# Altium schematic sheet I2CInterface.SchDoc(I2CInterface)
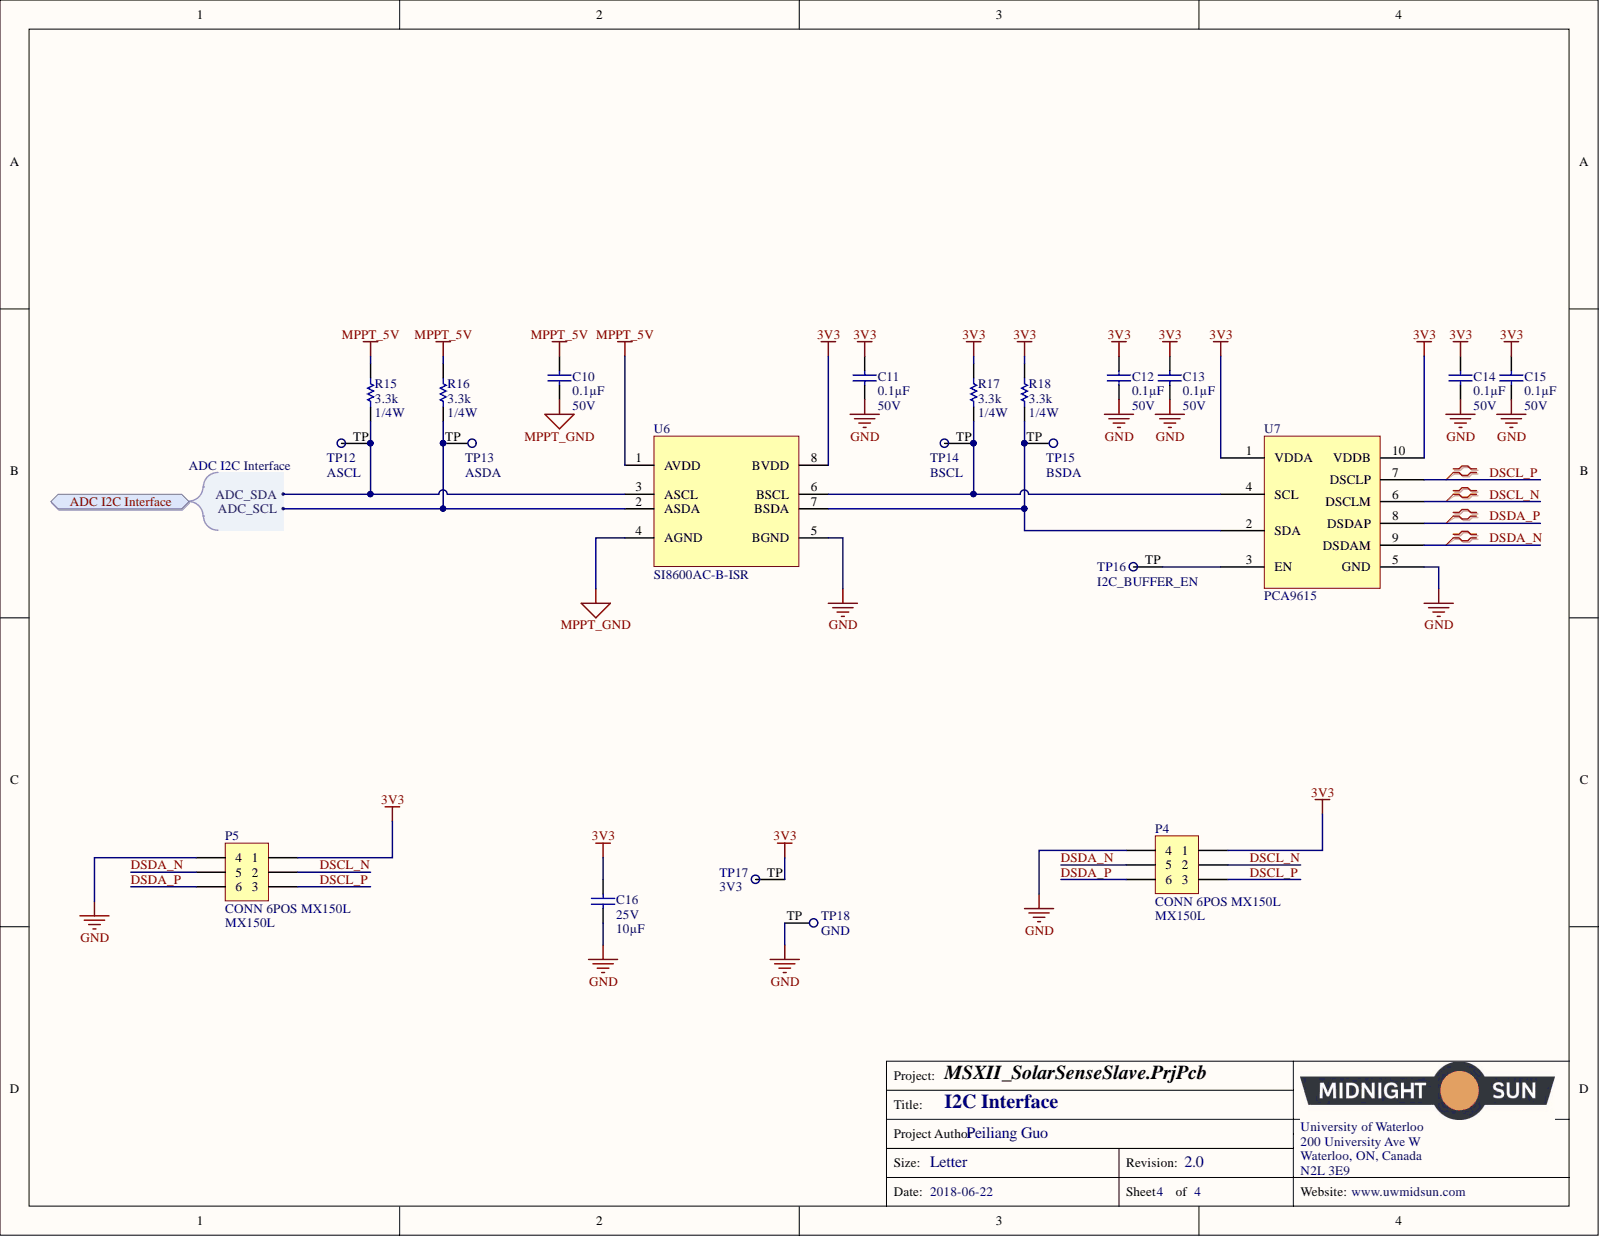
[diagram p0: I2CInterface.SchDoc]

## Parts
C10  Voltage: 50V  Capacitance: 0.1µF  [CAP, 0603]  (hidden comment: CAP CER 0.1UF 50V 10% X7R 0603)
C11  Voltage: 50V  Capacitance: 0.1µF  [CAP, 0603]  (hidden comment: CAP CER 0.1UF 50V 10% X7R 0603)
C12  Voltage: 50V  Capacitance: 0.1µF  [CAP, 0603]  (hidden comment: CAP CER 0.1UF 50V 10% X7R 0603)
C13  Voltage: 50V  Capacitance: 0.1µF  [CAP, 0603]  (hidden comment: CAP CER 0.1UF 50V 10% X7R 0603)
C14  Voltage: 50V  Capacitance: 0.1µF  [CAP, 0603]  (hidden comment: CAP CER 0.1UF 50V 10% X7R 0603)
C15  Voltage: 50V  Capacitance: 0.1µF  [CAP, 0603]  (hidden comment: CAP CER 0.1UF 50V 10% X7R 0603)
C16  Voltage - Rated: 25V  Capacitance: 10µF  [CAP, 0805]  (hidden comment: CAP CER 10uF 25V 10% X5R 0805)
P4  CONN 6POS MX150L  series: MX150L  [CONN, 6POS MX150L]
P5  CONN 6POS MX150L  series: MX150L  [CONN, 6POS MX150L]
R15  Resistance: 3.3k  Power: 1/4W  [RES, 0603]  (hidden comment: RES 3.3K OHM 1% 1/4W 0603)
R16  Resistance: 3.3k  Power: 1/4W  [RES, 0603]  (hidden comment: RES 3.3K OHM 1% 1/4W 0603)
R17  Resistance: 3.3k  Power: 1/4W  [RES, 0603]  (hidden comment: RES 3.3K OHM 1% 1/4W 0603)
R18  Resistance: 3.3k  Power: 1/4W  [RES, 0603]  (hidden comment: RES 3.3K OHM 1% 1/4W 0603)
TP12  ASCL  [Test Point]  (no BOM)
TP13  ASDA  [Test Point]  (no BOM)
TP14  BSCL  [Test Point]  (no BOM)
TP15  BSDA  [Test Point]  (no BOM)
TP16  I2C_BUFFER_EN  [Test Point]  (no BOM)
TP17  3V3  [Test Point]  (no BOM)
TP18  GND  [Test Point]  (no BOM)
U6  Manufacturer Part Number 1: SI8600AC-B-ISR  [IC DGTL ISO 3.75KV I2C 8SOIC NARROW BODY]  (hidden comment: IC DGTL ISO I2C 3.75KV 2CH 8SOIC)
U7  Base Part Number: PCA9615  [TSSOP50P490X110-10N]  (hidden comment: IC DIFFERENTIAL I2C BUFFER PCA9615)

## Ports
ADC I2C Interface, ADC I2C Interface.ADC_SCL, ADC I2C Interface.ADC_SDA

## Nets
3V3: C11.1 C12.1 C13.1 C14.1 C15.1 C16.1 P4.1 P5.1 R17.1 R18.1 TP17.TP U6.8(BVDD) U7.1(VDDA) U7.10(VDDB)
GND: C11.2 C12.2 C13.2 C14.2 C15.2 C16.2 P4.4 P5.4 TP18.TP U6.5(BGND) U7.5(GND)
MPPT_5V: C10.1 R15.1 R16.1 U6.1(AVDD)
MPPT_GND: C10.2 U6.4(AGND)
DSCL_N: P4.2 P5.2 U7.6(DSCLM)
DSCL_P: P4.3 P5.3 U7.7(DSCLP)
DSDA_N: P4.5 P5.5 U7.9(DSDAM)
DSDA_P: P4.6 P5.6 U7.8(DSDAP)
NetR15_2: R15.2 TP12.TP U6.3(ASCL) ; port ADC I2C Interface
NetR16_2: R16.2 TP13.TP U6.2(ASDA) ; port ADC I2C Interface
NetR17_2: R17.2 TP14.TP U6.6(BSCL) U7.4(SCL)
NetR18_2: R18.2 TP15.TP U6.7(BSDA) U7.2(SDA)
NetTP16_TP: TP16.TP U7.3(EN)

## Library data of the parts
C10-C15 = CAP CER 0.1UF 50V 10% X7R 0603: 0.10µF ±10% 50V Ceramic Capacitor X7R 0603 (1608 Metric); Manufacturer Part Number 1: 06035C104KAT2A; Manufacturer 1: AVX Corporation; Tolerance: ±10%; Thickness (Max): 0.035" (0.90mm); Temperature Coefficient: X7R; Supplier Part Number 1: 478-5052-1-ND; Supplier 1: Digi-Key; Size / Dimension: 0.063" L x 0.032" W (1.60mm x 0.81mm); Ratings: -; Part Status: Active; Packaging: Cut Tape (CT); Package / Case: 0603 (1608 Metric); Operating Temperature: -55°C ~ 125°C; Mounting Type: Surface Mount, MLCC; Lead Style: -; Lead Spacing: -; Height - Seated (Max): -; ComponentLink1URL: http://datasheets.avx.com/X7RDielectric.pdf; ComponentLink1Description: http://datasheets.avx.com/X7RDielectric.pdf; Component Kind: Standard; Library Name: Schematic Diagrams.SchLib
C16 = CAP CER 10uF 25V 10% X5R 0805: 10µF ±10% 25V Ceramic Capacitor X5R 0805 (2012 Metric); Manufacturer Part Number: GRM21BR61E106KA73L; Manufacturer: Murata Electronics North America; Tolerance: ±10%; Thickness (Max): 0.053" (1.35mm); Temperature Coefficient: X5R; Supplier Part Number 1: 490-5523-1-ND; Supplier 1: Digi-Key; Stock 1: 1695157; Size / Dimension: 0.079" L x 0.049" W (2.00mm x 1.25mm); RoHS: RoHS Compliant; Ratings: -; Pricing 1: 1=0.3, 10=0.214, 100=0.1008, 500=0.07068, 1000=0.05851 (CAD); Part Status: Active; Packaging: Cut Tape (CT); Package / Case: 0805 (2012 Metric); Operating Temperature: -55°C ~ 85°C; Mounting Type: Surface Mount, MLCC; Lead Style: -; Lead Spacing: -; Height - Seated (Max): -; Features: -; ComponentLink1URL: http://search.murata.co.jp/Ceramy/image/img/A01X/G101/ENG/GRM21BR61E106KA73-01.pdf; ComponentLink1Description: http://search.murata.co.jp/Ceramy/image/img/A01X/G101/ENG/GRM21BR61E106KA73-01.pdf; Component Kind: Standard; Category: Capacitors; Applications: General Purpose; Library Name: Schematic Diagrams.SchLib
P4 P5 = CONN 6POS MX150L: Connector Header Panel Mount, Through Hole, Right Angle 6 position 0.230" (5.84mm); Manufacturer Part Number: 19427-0018; Manufacturer: Molex; Voltage Rating: 600V; Row Spacing: 0.23inch; Number of Positions: 6; Glow Wire Compliant: Non-compliant; Gender: Male; Current Rating: 18A; Connector Type: Male Pin; Termination: Solder; Supplier Part Number 1: WM17506-ND; Supplier 1: Digi-Key; Stock 1: 2808; Radiation Hardening: No; Pricing 1: 1=6.02, 10=5.773, 100=4.769, 500=4.016, 1000=3.514 (USD); Pitch: 5.84mm; Packaging: Tray; Package Quantity: 1; Orientation: Right Angle; Number of Rows: 2; Number of Contacts: 6; Number of Circuits: 6; Mount: Through Hole,Panel; Min Operating Temperature: -40°C; Max Operating Temperature: 125°C; Lead Free: Lead Free; Housing Material: Polyester; Housing Colour: Black; Flammability Rating: UL94 V-0; Fastening Type: Ramp; ELV: Compliant; Contact Plating: Nickel,Tin; Contact Material: Copper Alloy; ComponentLink3URL: https://datasheet.ciiva.com/714/194270018-sd-714250.pdf; ComponentLink3Description: https://datasheet.ciiva.com/714/194270018-sd-714250.pdf; ComponentLink2URL: https://datasheet.ciiva.com/16725/0194270018-pcb-headers-16725700.pdf; ComponentLink2Description: https://datasheet.ciiva.com/16725/0194270018-pcb-headers-16725700.pdf; ComponentLink1URL: https://datasheet.ciiva.com/4554/0194270018-pcb-headers-135602-4554916.pdf; ComponentLink1Description: https://datasheet.ciiva.com/4554/0194270018-pcb-headers-135602-4554916.pdf; Component Kind: Standard; Colour: Black; Library Name: Schematic Diagrams.SchLib
R15-R18 = RES 3.3K OHM 1% 1/4W 0603: 3.3k Ohm ±1% 0.25W, 1/4W Chip Resistor 0603 (1608 Metric) Automotive AEC-Q200, Pulse Withstanding Thick Film; Manufacturer Part Number 1: ERJ-PA3F3301V; Manufacturer 1: Panasonic Electronic Components; Temperature Coefficient: ±100ppm/°C; Tolerance: ±1%; Supplier Part Number 1: P3.3KBYCT-ND; Supplier Device Package: 0603; Supplier 1: Digi-Key; Size / Dimension: 0.063" L x 0.031" W (1.60mm x 0.80mm); Part Status: Active; Packaging: Cut Tape (CT); Package / Case: 0603 (1608 Metric); Operating Temperature: -55°C ~ 155°C; Height - Seated (Max): 0.022" (0.55mm); Failure Rate: -; Composition: Thick Film; ComponentLink1URL: https://industrial.panasonic.com/cdbs/www-data/pdf/RDO0000/AOA0000C291.pdf; ComponentLink1Description: https://industrial.panasonic.com/cdbs/www-data/pdf/RDO0000/AOA0000C291.pdf; Component Kind: Standard; Library Name: Schematic Diagrams.SchLib
TP12-TP18 = Test Point: Library Name: Schematic Diagrams.SchLib
U6 = IC DGTL ISO I2C 3.75KV 2CH 8SOIC: I²C Digital Isolator 3750Vrms 2 Channel 35kV/µs CMTI 8-SOIC (0.154", 3.90mm Width); Manufacturer 1: Silicon Labs; Pulse Width Distortion (PWD): 32ns; Propagation Delay: 26ns; Polarity: Bidirectional; Number of Channels: 2; Isolated Power: No; Data Rate: 10Mbps; Weight: 0.006596oz; Supplier Part Number 1: SI8600AC-B-ISRCT-ND; Supplier 1: Digi-Key; Stock 1: 4033; Pricing 1: 1=3.33, 25=3.2084, 100=3.0849 (USD); Packaging: Tape and Reel; Package Quantity: 2500; Number of Pins: 8; Min Operating Temperature: -40°C; Max Operating Temperature: 125°C; ComponentLink1URL: https://datasheet.ciiva.com/14921/supportdocuments2ftechnicaldocs2fsi860x-14921653.pdf; ComponentLink1Description: https://datasheet.ciiva.com/14921/supportdocuments2ftechnicaldocs2fsi860x-14921653.pdf; Component Kind: Standard; Channel Type: Bidirectional; Case/Package: SOIC; Library Name: Schematic Diagrams.SchLib
U7 = IC DIFFERENTIAL I2C BUFFER PCA9615: Buffer, ReDriver 2 Channel 400kHz 10-TSSOP; Manufacturer Part Number: PCA9615DPJ; Manufacturer: NXP USA Inc.; Voltage - Supply: 2.3 V ~ 5.5 V; Output: 2-Wire Bus; Number of Channels: 2; Current - Supply: 16µA; Type: Buffer, ReDriver; Supplier Part Number 1: 568-11484-1-ND; Supplier Device Package: 10-TSSOP; Supplier 1: Digi-Key; Stock 1: 7673; Signal Conditioning: -; RoHS: RoHS Compliant; Pricing 1: 1=4.38, 10=3.93, 100=3.2205, 500=2.74156, 1000=2.31216 (CAD); Part Status: Active; Packaging: Cut Tape (CT); Package / Case: 10-TFSOP, 10-MSOP (0.118", 3.00mm Width); Operating Temperature: -40°C ~ 85°C; Input: 2-Wire Bus; Delay Time: -; Data Rate (Max): 400kHz; ComponentLink1URL: http://www.nxp.com/documents/data_sheet/PCA9615.pdf; ComponentLink1Description: http://www.nxp.com/documents/data_sheet/PCA9615.pdf; Component Kind: Standard; Category: Integrated Circuits (ICs); Applications: I²C; Library Name: Schematic Diagrams.SchLib
